# Altium schematic sheet CLK INOUT AND ENABLE INOUT.SchDoc
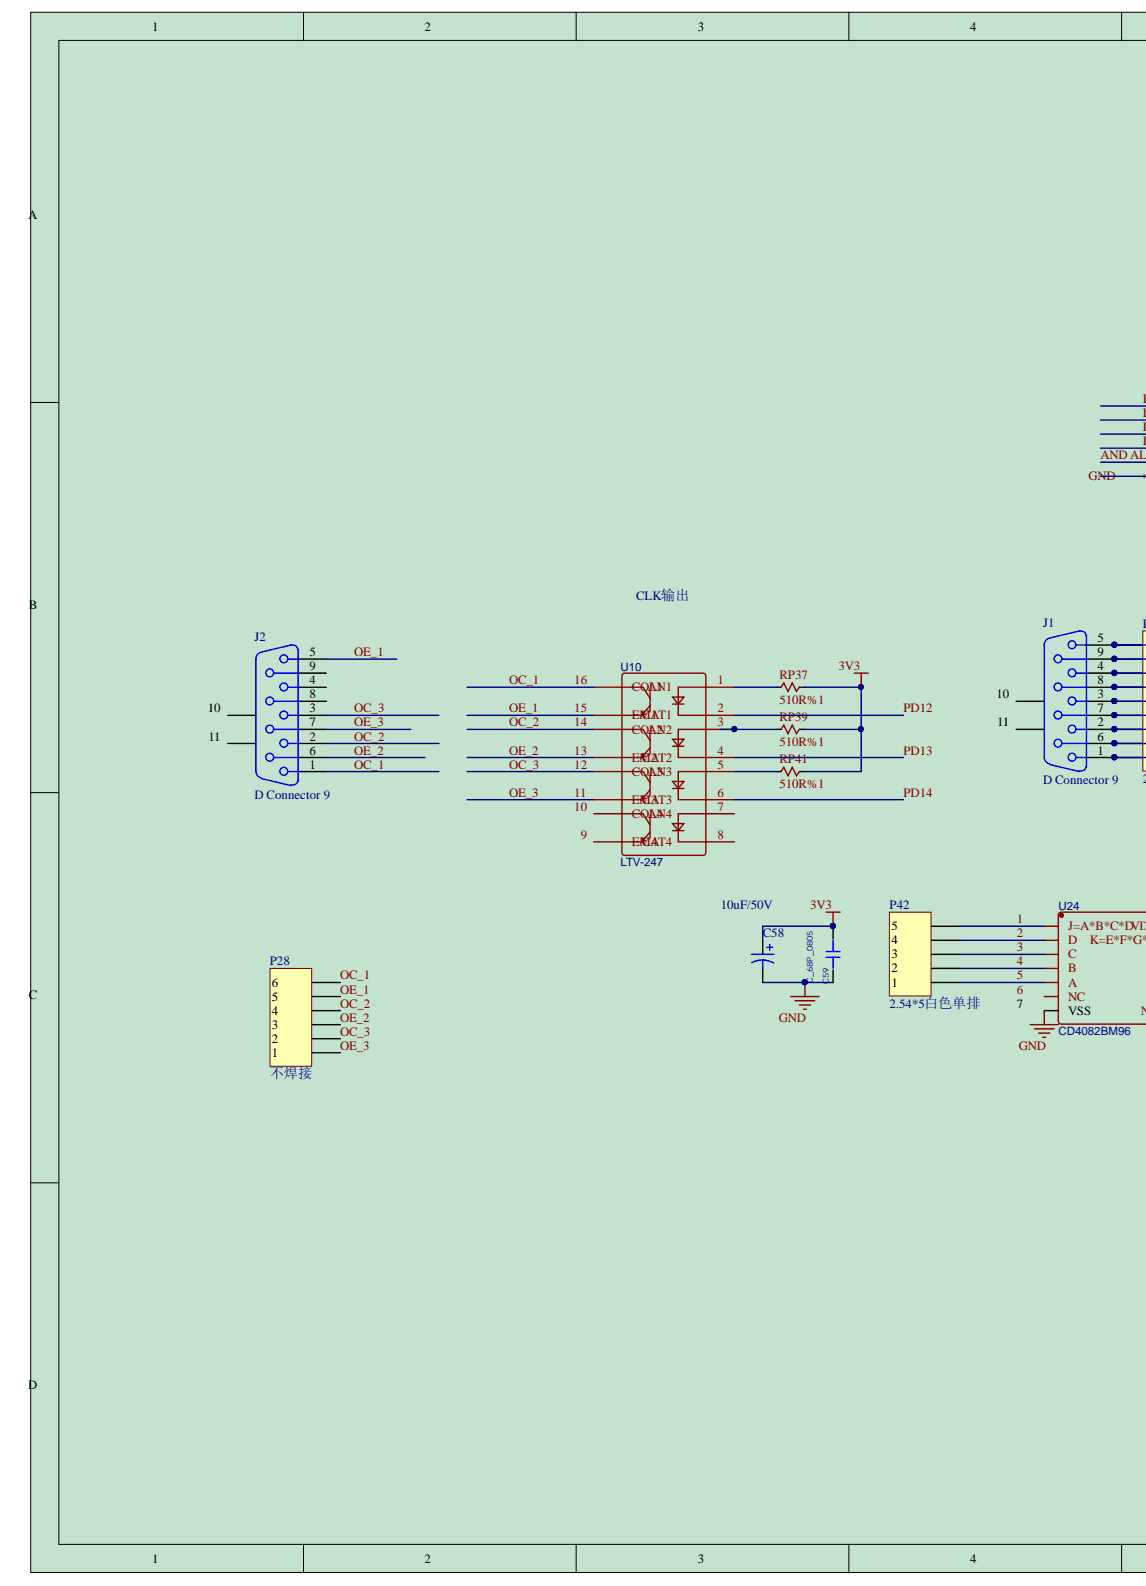
[diagram p0: CLK INOUT AND ENABLE INOUT.SchDoc, left]
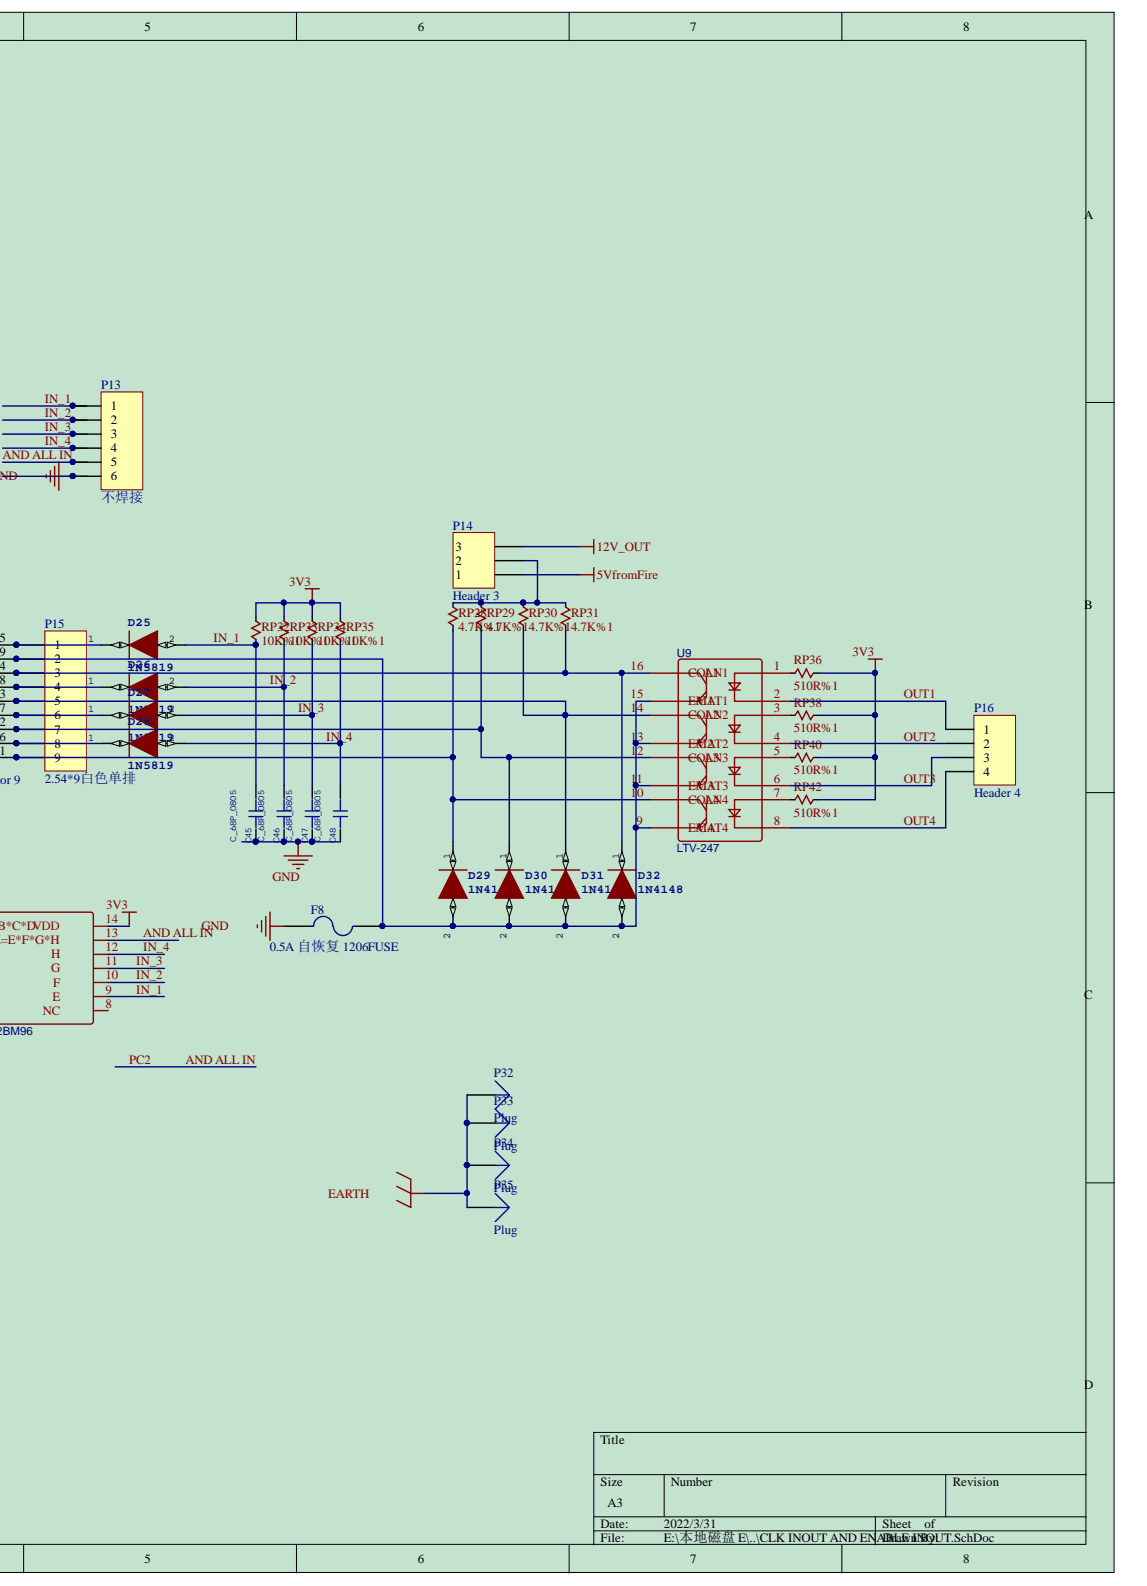
[diagram p1: CLK INOUT AND ENABLE INOUT.SchDoc, right]

## Parts
C45  C_68P_0805  [cap0805]
C46  C_68P_0805  [cap0805]
C47  C_68P_0805  [cap0805]
C48  C_68P_0805  [cap0805]
C58  10uF/50V  [C1210_N]
C59  C_68P_0805  [cap0805]
D25  1N5819  [SOD123]
D26  1N5819  [SOD123]
D27  1N5819  [SOD123]
D28  1N5819  [SOD123]
D29  1N4148  [SOD123]
D30  1N4148  [SOD123]
D31  1N4148  [SOD123]
D32  1N4148  [SOD123]
F8  0.5A 自恢复 1206FUSE  [1206_FUSE]
J1  D Connector 9  [DB9]
J2  D Connector 9  [DB9]
P13  不焊接  [CON6]
P14  Header 3  [HDR1X3]
P15  2.54*9白色单排  [CON9-2.54 - duplicate]
P16  Header 4  [HDR1X4]
P28  不焊接  [HDR1X6]
P32  Plug  [HOLE]
P33  Plug  [HOLE]
P34  Plug  [HOLE]
P35  Plug  [HOLE]
P42  2.54*5白色单排  [2.54*5单排白座]
RP28  4.7K%1  [R0805]
RP29  4.7K%1  [R0805]
RP30  4.7K%1  [R0805]
RP31  4.7K%1  [R0805]
RP32  10K%1  [R0805]
RP33  10K%1  [R0805]
RP34  10K%1  [R0805]
RP35  10K%1  [R0805]
RP36  510R%1  [R0805]
RP37  510R%1  [R0805]
RP38  510R%1  [R0805]
RP39  510R%1  [R0805]
RP40  510R%1  [R0805]
RP41  510R%1  [R0805]
RP42  510R%1  [R0805]
U9  LTV-247  [SOP-16_L10.3-W5.4-P1.27-LS7.8-BL]
U10  LTV-247  [SOP-16_L10.3-W5.4-P1.27-LS7.8-BL]
U24  CD4082BM96  [SOIC-14_L8.7-W3.9-P1.27-LS6.0-BL]

## Nets
3V3: C58.1 C59.2 RP32.1 RP33.1 RP34.1 RP35.1 RP36.2 RP37.2 RP38.2 RP39.2 RP40.2 RP41.2 RP42.2 U24.14
5VFROMFIRE: P14.1
12V_OUT: P14.3
EARTH: P32.1 P33.1 P34.1 P35.1
GND: C45.1 C46.1 C47.1 C48.1 C58.2 C59.1 F8.1 P13.6 U24.7(VSS)
AND ALL IN: P13.5 U24.13
IN_1: C45.2 D25.2 P13.1 RP32.2 U24.9
IN_2: C46.2 D26.2 P13.2 RP33.2 U24.10
IN_3: C47.2 D27.2 P13.3 RP34.2 U24.11
IN_4: C48.2 D28.2 P13.4 RP35.2 U24.12
OC_1: J2.1 P28.6 U10.16(COL1)
OC_2: J2.2 P28.4 U10.14(COL2)
OC_3: J2.3 P28.2 U10.12(COL3)
OE_1: J2.5 P28.5 U10.15(EM1)
OE_2: J2.6 P28.3 U10.13(EM2)
OE_3: J2.7 P28.1 U10.11(EM3)
OUT1: P16.1 U9.2
OUT2: P16.2 U9.4
OUT3: P16.3 U9.6
OUT4: P16.4 U9.8
PD12: U10.2
PD13: U10.4
PD14: U10.6
NetD25_1: D25.1 J1.5 P15.1
NetD26_1: D26.1 J1.8 P15.4
NetD27_1: D27.1 J1.7 P15.6
NetD28_1: D28.1 J1.6 P15.8
NetD29_1: D29.1 J1.1 P15.9 RP28.2 U9.10(COL4)
NetD29_2: D29.2 D30.2 D31.2 D32.2 F8.2 J1.9 P15.2 U9.9(EM4) U9.11(EM3) U9.13(EM2) U9.15(EM1)
NetD30_1: D30.1 J1.2 P15.7 RP29.2 U9.12(COL3)
NetD31_1: D31.1 J1.3 P15.5 RP30.2 U9.14(COL2)
NetD32_1: D32.1 J1.4 P15.3 RP31.2 U9.16(COL1)
NetP14_2: P14.2 RP28.1 RP29.1 RP30.1 RP31.1
NetP42_1: P42.1 U24.5(A)
NetP42_2: P42.2 U24.4(B)
NetP42_3: P42.3 U24.3(C)
NetP42_4: P42.4 U24.2(D)
NetP42_5: P42.5 U24.1(J=A*B*C*D)
NetRP36_1: RP36.1 U9.1
NetRP37_1: RP37.1 U10.1
NetRP38_1: RP38.1 U9.3
NetRP39_1: RP39.1 U10.3
NetRP40_1: RP40.1 U9.5
NetRP41_1: RP41.1 U10.5
NetRP42_1: RP42.1 U9.7

Not connected: J1 10 11; J2 4 8 9 10 11; U10 7 8 9(EM4) 10(COL4); U24 6(NC) 8

## Library data of the parts
C45-C48 C59 = C_22P_0603: PCB DECAL: C_0603; Component Kind: Standard
C58 = Cap Pol2: Polarized Capacitor (Axial); Value: 10uF; Component Kind: Standard; Library Name: Miscellaneous Devices.IntLib; Published: 8-Jun-2000; Revision: July-2002: Re-released for DXP Platform.; Signal Integrity: Cap Pol; Simulation: CAP; Publisher: Altium Limited
D25-D32 = 1N5819: Manufacturer_Name: STMicroelectronics; Component Kind: Standard; Height: mm; Library Name: Schlib1.SchLib; Manufacturer_Part_Number: 1N5819; Mouser_Part_Number: 511-1N5819; Mouser_Price-Stock: https://www.mouser.co.uk/ProductDetail/STMicroelectronics/1N5819?qs=G5AQjGfRJcKKUy9S%252BaTHRQ%3D%3D
F8 = Fuse 2: Fuse; Component Kind: Standard; LatestRevisionDate: 17-Jul-2002; LatestRevisionNote: Re-released for DXP Platform.; PackageReference: PIN-W2/E2.8; Published: 8-Jun-2000; Simulation: FUSE; Library Name: jinrylib.IntLib; Publisher: Altium Limited
J1 J2 = D Connector 9: Receptacle Assembly, 9 Position, Right Angle; PackageDocument: O; Positions: 9; Component Kind: Standard; DatasheetDocument: 1-Sep-1999; Gender: Receptacle; LatestRevisionDate: 17-Jul-2002; LatestRevisionNote: Re-released for DXP Platform.; Library Name: Miscellaneous Connectors.IntLib; Orientation: Right Angle; PackageReference: 788750; Published: 15-Jun-2001; Signal Integrity: Connector; Publisher: Altium Limited
P13 P28 = Header 6: Header, 6-Pin; Component Kind: Standard; LatestRevisionDate: 17-Jul-2002; LatestRevisionNote: Re-released for DXP Platform.; Library Name: Miscellaneous Connectors.IntLib; Signal Integrity: Connector; Publisher: Altium Limited
P14 = Header 3: Header, 3-Pin; Component Kind: Standard; LatestRevisionDate: 17-Jul-2002; LatestRevisionNote: Re-released for DXP Platform.; Library Name: Miscellaneous Connectors.IntLib; Signal Integrity: Connector; Publisher: Altium Limited
P15 = Header 9: Header, 9-Pin; Component Kind: Standard; LatestRevisionDate: 17-Jul-2002; LatestRevisionNote: Re-released for DXP Platform.; Library Name: Miscellaneous Connectors.IntLib; Publisher: Altium Limited
P16 = Header 4: Header, 4-Pin; Component Kind: Standard; LatestRevisionDate: 17-Jul-2002; LatestRevisionNote: Re-released for DXP Platform.; Library Name: Miscellaneous Connectors.IntLib; Signal Integrity: Connector; Publisher: Altium Limited
P42 = Header 5: Header, 5-Pin; Component Kind: Standard; LatestRevisionDate: 17-Jul-2002; LatestRevisionNote: Re-released for DXP Platform.; Library Name: Miscellaneous Connectors.IntLib; Signal Integrity: Connector; Publisher: Altium Limited
RP28-RP42 = RESISTOR: Generic Resistor; Value: 4.7K; Component Kind: Standard; Library Name: Digilent.IntLib
U9 U10 = LTV-247: BOM_Manufacturer: LITEON; Contributor: LCSC; BOM_JLCPCB Part Class: Extended Part; BOM_Manufacturer Part: LTV-247; BOM_Supplier: LCSC; BOM_Supplier Part: C115451; Component Kind: Standard; Library Name: Schlib1.SchLib; spicePre: U; spiceSymbolName: LTV-247
U24 = CD4082BM96: BOM_Manufacturer: Texas Instruments; Contributor: LCSC; BOM_JLCPCB Part Class: Extended Part; BOM_Manufacturer Part: CD4082BM96; BOM_Supplier: LCSC; BOM_Supplier Part: C193801; Component Kind: Standard; Library Name: Schlib1.SchLib; spicePre: U; spiceSymbolName: CD4082BM96
P32-P35 = Plug: Component Kind: Standard; Description: Plug; LatestRevisionDate: 17-Jul-2002; LatestRevisionNote: Re-released for DXP Platform.; Library Name: Miscellaneous Connectors.IntLib; Signal Integrity: Connector; Publisher: Altium Limited
